# Altium schematic sheet RC and Telem.SchDoc("RC and Telem")
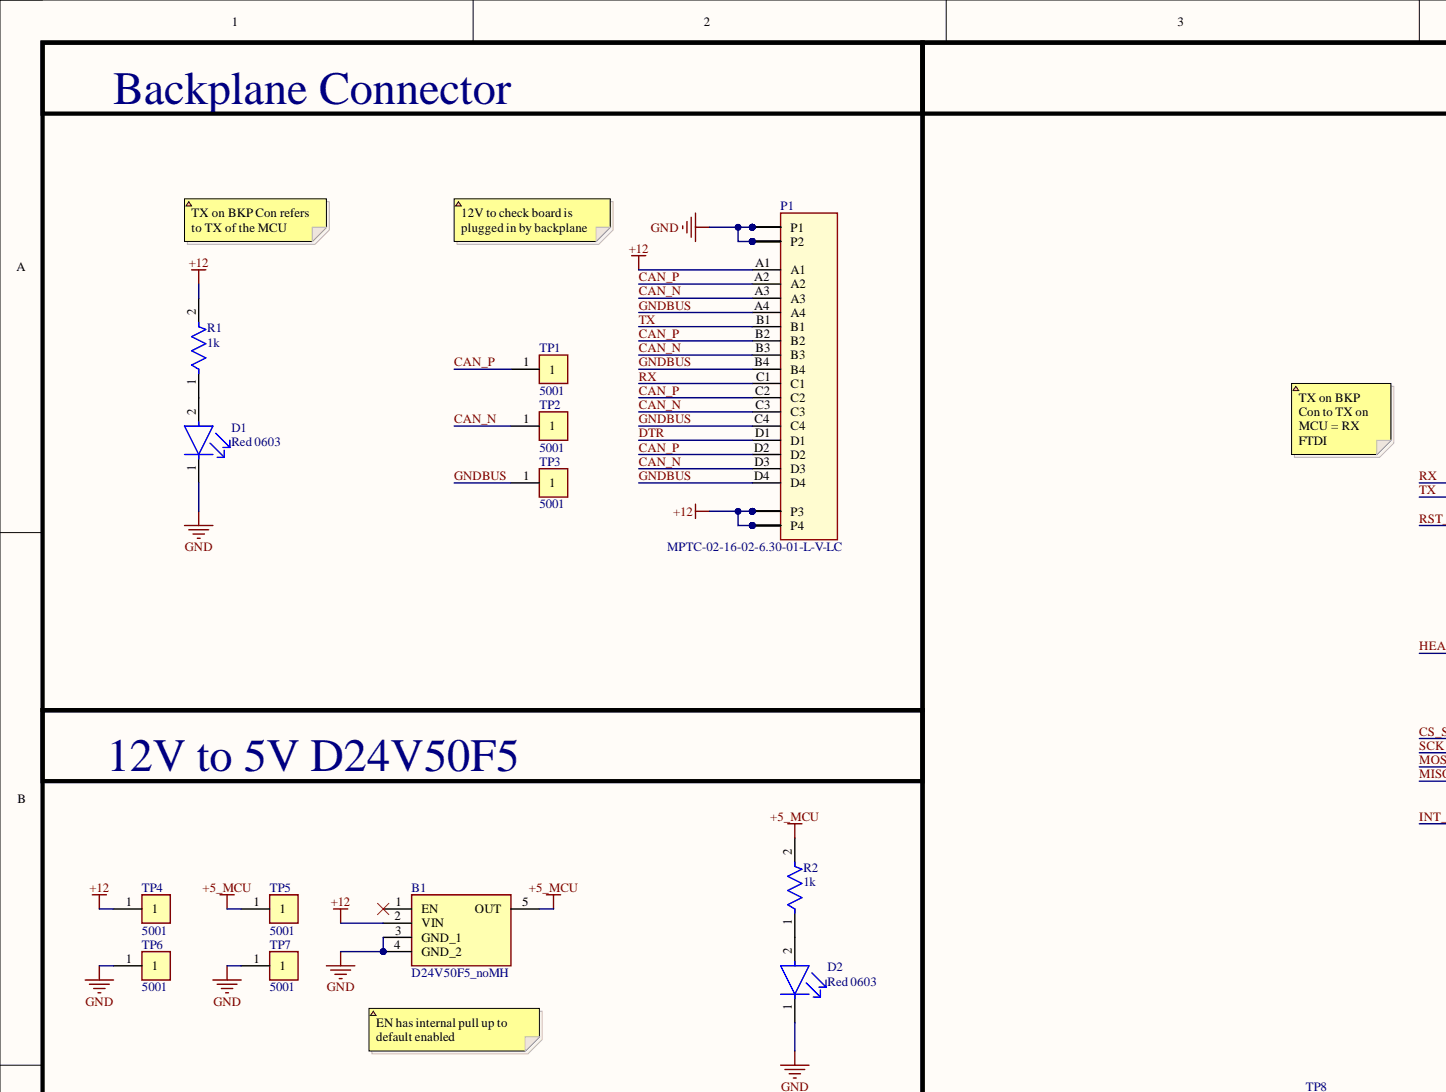
[diagram p0: RC and Telem.SchDoc, top left]
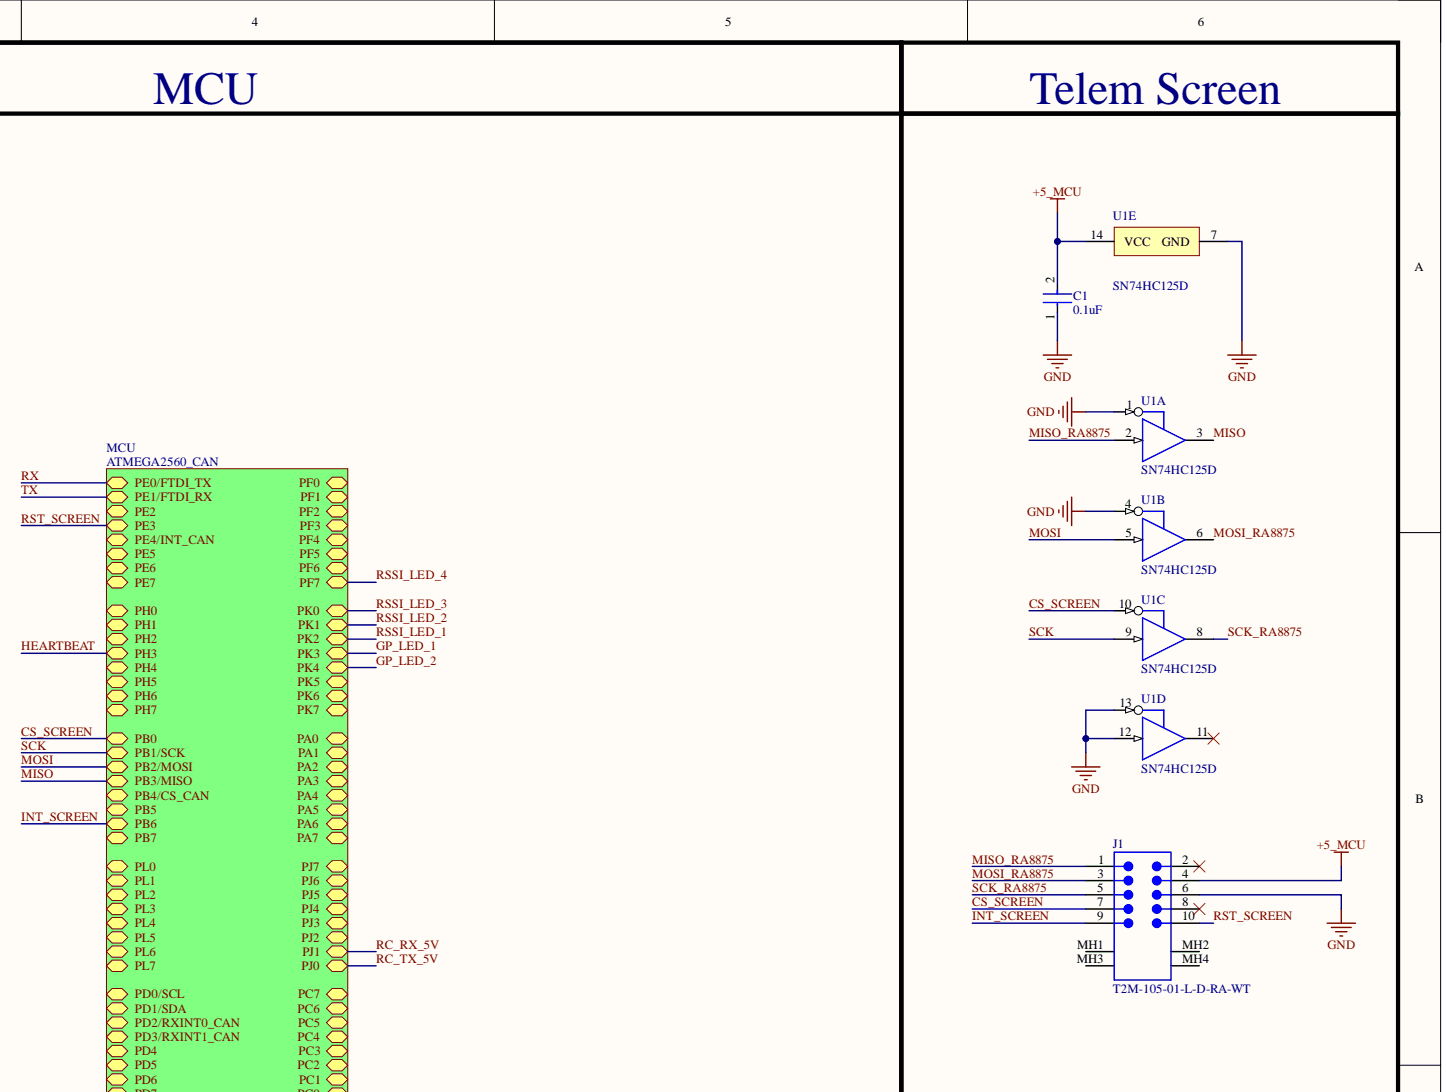
[diagram p1: RC and Telem.SchDoc, top right]
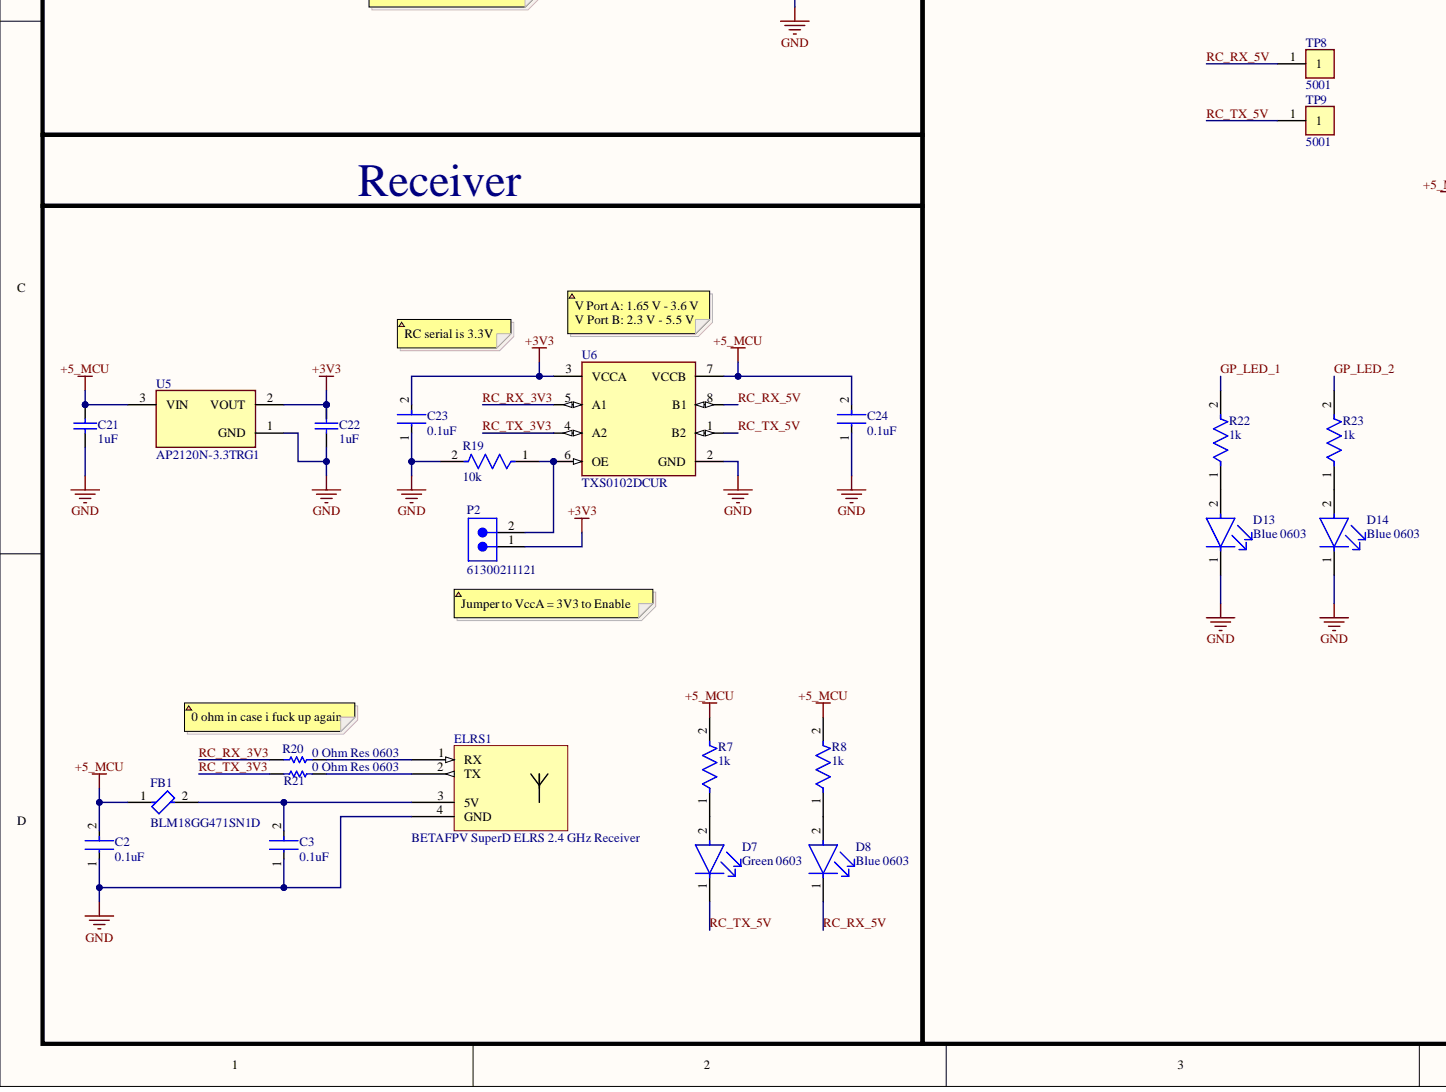
[diagram p2: RC and Telem.SchDoc, bottom left]
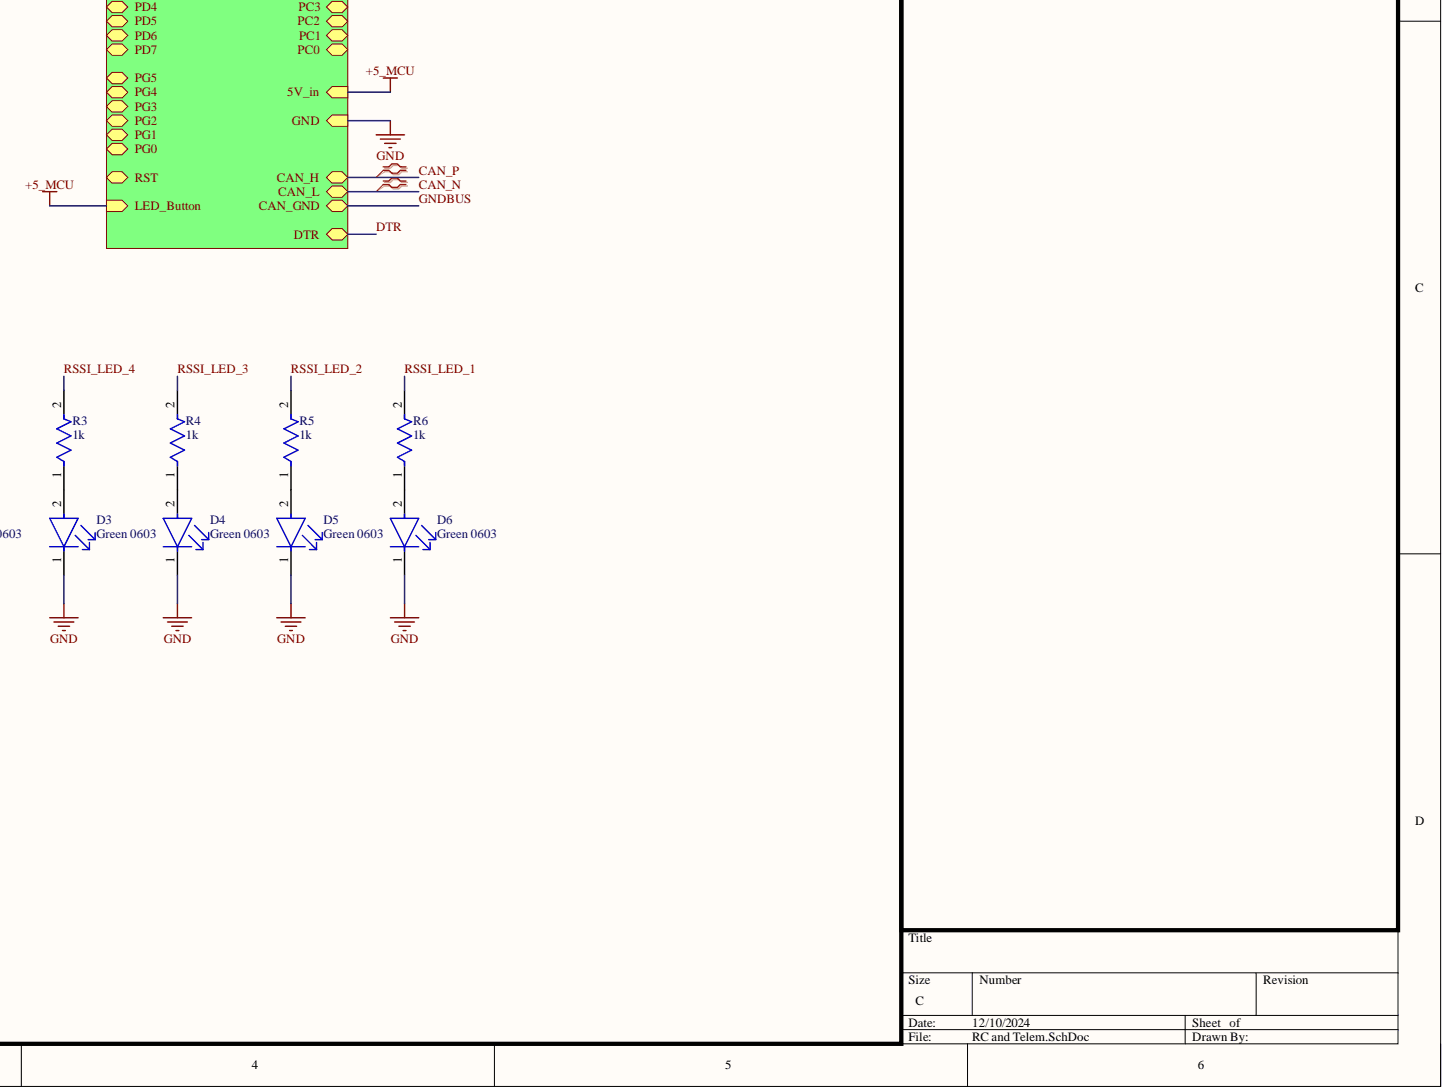
[diagram p3: RC and Telem.SchDoc, bottom right]

## Parts
B1  D24V50F5_noMH
C1  0.1uF
C2  0.1uF
C3  0.1uF
C21  1uF
C22  1uF
C23  0.1uF
C24  0.1uF
D1  Red 0603  Case Code (Imperial): 0603  Color: Red
D2  Red 0603  Case Code (Imperial): 0603  Color: Red
D3  Green 0603  Color: Green
D4  Green 0603  Case Code (Imperial): 0603  Color: Green
D5  Green 0603  Case Code (Imperial): 0603  Color: Green
D6  Green 0603  Case Code (Imperial): 0603  Color: Green
D7  Green 0603  Color: Green
D8  Blue 0603  Case Code (Imperial): 0603  Color: Blue
D13  Blue 0603  Case Code (Imperial): 0603  Color: Blue
D14  Blue 0603  Case Code (Imperial): 0603  Color: Blue
ELRS1  BETAFPV SuperD ELRS 2.4 GHz Receiver
FB1  BLM18GG471SN1D
J1  T2M-105-01-L-D-RA-WT
P1  MPTC-02-16-02-6.30-01-L-V-LC
P2  61300211121
R1  1k
R2  1k
R3  1k
R4  1k
R5  1k
R6  1k
R7  1k
R8  1k
R19  10k
R20  0 Ohm Res 0603
R21  0 Ohm Res 0603
R22  1k
R23  1k
TP1  5001
TP2  5001
TP3  5001
TP4  5001
TP5  5001
TP6  5001
TP7  5001
TP8  5001
TP9  5001
U1  SN74HC125D  (parts A,B,C,D,E)
U5  AP2120N-3.3TRG1
U6  TXS0102DCUR

## Nets
+3V3: C22.2 C23.2 P2.1 U5.2 U6.3(VCCA)
+5_MCU: B1.5(OUT) C1.2 C2.2 C21.2 C24.2 FB1.1 J1.4 R2.2 R7.2 R8.2 TP5.1 U1.14(VCC) U5.3 U6.7(VCCB)
+12: B1.2(VIN) P1.A1 P1.P3.1(P3) P1.P3.2 P1.P3.3 P1.P3.4 P1.P3.5 P1.P3.6 P1.P4.1(P4) P1.P4.2 P1.P4.3 P1.P4.4 P1.P4.5 P1.P4.6 R1.2 TP4.1
GND: B1.3(GND_1) B1.4(GND_2) C1.1 C2.1 C3.1 C21.1 C22.1 C23.1 C24.1 D1.1 D2.1 D3.1 D4.1 D5.1 D6.1 D13.1 D14.1 ELRS1.4(GND) J1.6 P1.P1.1 P1.P1.2 P1.P1.3 P1.P1.4 P1.P1.5 P1.P1.6 P1.P2.1(P2) P1.P2.2 P1.P2.3 P1.P2.4 P1.P2.5 P1.P2.6 R19.2 TP6.1 TP7.1 U1.1 U1.4 U1.7(GND) U1.12 U1.13 U5.1 U6.2(GND)
CAN_N: P1.A3 P1.B3 P1.C3 P1.D3 TP2.1
CAN_P: P1.A2 P1.B2 P1.C2 P1.D2 TP1.1
CS_SCREEN: J1.7 U1.10
DTR: P1.D1
GNDBUS: P1.A4 P1.B4 P1.C4 P1.D4 TP3.1
GP_LED_1: R22.2
GP_LED_2: R23.2
INT_SCREEN: J1.9
MISO: U1.3
MISO_RA8875: J1.1 U1.2
MOSI: U1.5
MOSI_RA8875: J1.3 U1.6
RC_RX_3V3: R20.1 U6.5(A1)
RC_RX_5V: D8.1 TP8.1 U6.8(B1)
RC_TX_3V3: R21.1 U6.4(A2)
RC_TX_5V: D7.1 TP9.1 U6.1(B2)
RSSI_LED_1: R6.2
RSSI_LED_2: R5.2
RSSI_LED_3: R4.2
RSSI_LED_4: R3.2
RST_SCREEN: J1.10
RX: P1.C1
SCK: U1.9
SCK_RA8875: J1.5 U1.8
TX: P1.B1
NetB1_1: B1.1(EN)
NetC3_2: C3.2 ELRS1.3(5V) FB1.2
NetD1_2: D1.2 R1.1
NetD2_2: D2.2 R2.1
NetD3_2: D3.2 R3.1
NetD4_2: D4.2 R4.1
NetD5_2: D5.2 R5.1
NetD6_2: D6.2 R6.1
NetD7_2: D7.2 R7.1
NetD8_2: D8.2 R8.1
NetD13_2: D13.2 R22.1
NetD14_2: D14.2 R23.1
NetELRS1_1: ELRS1.1(RX) R20.2
NetELRS1_2: ELRS1.2(TX) R21.2
NetJ1_2: J1.2
NetJ1_8: J1.8
NetJ1_MH1: J1.MH1
NetJ1_MH2: J1.MH2
NetJ1_MH3: J1.MH3
NetJ1_MH4: J1.MH4
NetP2_2: P2.2 R19.1 U6.6(OE)
NetU1_11: U1.11
HEARTBEAT:
PA0:
PA1:
PA2:
PA3:
PA4:
PA5:
PA6:
PA7:
PB4/CS_CAN:
PB5:
PB7:
PC0:
PC1:
PC2:
PC3:
PC4:
PC5:
PC6:
PC7:
PD0/SCL:
PD1/SDA:
PD2/RXINT0_CAN:
PD3/RXINT1_CAN:
PD4:
PD5:
PD6:
PD7:
PE2:
PE4/INT_CAN:
PE5:
PE6:
PE7:
PF0:
PF1:
PF2:
PF3:
PF4:
PF5:
PF6:
PG0:
PG1:
PG2:
PG3:
PG4:
PG5:
PH0:
PH1:
PH2:
PH4:
PH5:
PH6:
PH7:
PJ2:
PJ3:
PJ4:
PJ5:
PJ6:
PJ7:
PK5:
PK6:
PK7:
PL0:
PL1:
PL2:
PL3:
PL4:
PL5:
PL6:
PL7:
RST:

## Library data of the parts
B1 = CMP-030-000002-4: Pololu 5V, 5A Step-Down Voltage Regulator D24V50F5 with no Mounting Hole; Geometry.Height: 8.77mm; Component Kind: Standard; Datasheet Link: https://www.pololu.com/file/0J1436/d24v50f5-step-down-voltage-regulator-dimensions.pdf; Design Item ID: D24V50F5; Manufacturer_Name: Pololu; Manufacturer_Part_Number: D24V50F5; Source: D24V50F5.SchLib; Library Name: BumbleBee Autonomous Systems - NUS
C1-C3 C23 C24 = CMP-2006-02218-2: GCJ188R71C104KA01D. Cap Ceramic 0.1uF 16V X7R 10% Pad SMD 0603 Soft Termination +125°C Automotive T/R; Capacitance: 100nF; Case Code (Imperial): 0603; Case Code (Metric): 1608; Case/Package: 0603; Component Kind: Standard; Depth: 0.8mm; Dielectric: X7R; Features: Soft Termination; Height: 0.8mm; Length: 1.6mm; Library Name: Altium Content Vault; Max Operating Temperature: 125°C; Min Operating Temperature: -55°C; Mount: Surface Mount; Package Quantity: 1; Packaging: Tape and Reel; Radiation Hardening: No; Ratings: AEC-Q200; Termination: SMD/SMT; Thickness: 0.035inch; Tolerance: 10%; Voltage Rating: 16V; Voltage Rating (DC): 16V; Width: 0.031inch
C21 C22 = CMP-1035-02736-2: 0603YC105JAT2A; Manufacturer: AVX Interconnect / Elco; Component Kind: Standard; Library Name: Altium Content Vault; Part Number: 0603YC105JAT2A
D1 D2 = CMP-00010-2: Led Red Diffused 0603 SMD; Manufacturer Part No.: 150060RS55040; Dominant Wavelength: 624nm; Forward Voltage: 2V; Lens Size: 0.8mm; Lens Style: Flat; Luminous Intensity: 90mcd; Test Current: 20mA; Viewing Angle: 140°; Case Code (Metric): 1608; Case/Package: 0603; Component Kind: Standard; Datasheet: https://www.farnell.com/datasheets/2621644.pdf?src-supplier=Farnell; Height: 0.5mm; Length: 1.6mm; Lens Transparency: Diffused; Mounting Technology: Surface Mount; Packaging: Cut Tape; RoHS Compliant: Yes; Series: WL-SMDC; Width: 0.8mm; Library Name: BumbleBee Autonomous Systems - NUS
D3-D7 = CMP-00011-1: Led, Green, 570 Nm, 2 V, 30 Ma, 40 Mcd Rohs Compliant: Yes; Manufacturer Part No.: 150060VS75000; Dominant Wavelength: 570nm; Forward Current: 20mA; Forward Voltage: 2V; Lens Color: Colorless; Lens Size: 0.7mm; Lens Style: Rectangular,Flat; Lens Transparency: Clear; Luminous Intensity: 40mcd; Power Rating: 72mW; Reverse Voltage (DC): 5V; Viewing Angle: 140°; Case Code (Metric): 1608; Case/Package: 0603; Component Kind: Standard; Height: 0.8mm; Illumination Color: Green; Length: 1.6mm; Max Operating Temperature: 85°C; Min Operating Temperature: -40°C; Mounting Technology: Surface Mount; Package Quantity: 4000; Packaging: Cut Tape; Pins: 2; RoHS Compliant: Yes; Series: WL-SMCW; Test Current: 20mA; Weight: 0.000882oz; Width: 0.8mm; Library Name: BumbleBee Autonomous Systems - NUS
  D3 D7: Case Code (Imperial): 0603
D8 D13 D14 = CMP-00012-1: Led, Blue, 470 Nm, 3.2 V, 30 Ma, 145 Mcd Rohs Compliant: Yes; Manufacturer Part No.: 150060BS75000; Dominant Wavelength: 470nm; Forward Current: 30mA; Forward Voltage: 3.2V; Lens Color: Colorless; Lens Size: 0.7mm; Lens Style: Clear,Flat,Rectangular; Lens Transparency: Clear; Luminous Intensity: 145mcd; Test Current: 20mA; Viewing Angle: 140°; Case Code (Metric): 1608; Case/Package: 0603; Component Kind: Standard; Height: 0.8mm; Length: 1.6mm; Max Operating Temperature: 85°C; Min Operating Temperature: -40°C; Mounting Technology: Surface Mount; Package Quantity: 1; Packaging: Cut Tape; Reach SVHC Compliant: Unknown; RoHS Compliant: Yes; Series: WL-SMCW; Wavelength: 470nm; Width: 0.8mm; Library Name: BumbleBee Autonomous Systems - NUS
ELRS1 = CMP-00058-3: https://betafpv.com/collections/rx/products/superd-elrs-2-4g-diversity-receiver?variant=39995057668230; Component Kind: Standard; Library Name: BumbleBee Autonomous Systems - NUS
FB1 = CMP-0686-00593-3: FERRITE BEAD 470 OHM 0603 1LN; Current Rating: 200mA; DC Resistance (DCR): 300mR; Impedance: 470R; Material: Steel; Number of Circuits: 1; Resistance: 1.3R; Test Frequency: 100MHz; Tolerance: 25%; Case Code (Imperial): 0603; Case Code (Metric): 1608; Case/Package: 0603; Component Kind: Standard; Contact Plating: Tin; Core Material: Ferrite; Depth: 0.8mm; Height: 0.8mm; Lead Free: Lead Free; Length: 1.6mm; Library Name: Altium Content Vault; Max Current Rating: 200mA; Max DC Current: 200mA; Max Operating Temperature: 125°C; Min Operating Temperature: -55°C; Number of Lines: 1; Number of Pins: 2; Package Quantity: 1; Packaging: Tape and Reel; REACH SVHC: No SVHC; Termination: Flat; Width: 0.8mm
J1 = CMP-1029-00151-1: High Reliability Cable Header, Through-hole, Right Angle, -55 to 125 degC, 2 mm Pitch, 14-Pin, Male, RoHS; Manufacturer: Samtec; Pitch: 2 mm; Component Kind: Standard; ComponentLink1Description: Manufacturer URL; ComponentLink1URL: http://www.samtec.com; ComponentLink2Description: Datasheet; ComponentLink2URL: http://d2a072wq521u33.cloudfront.net/catalog_english//t2m.pdf; ComponentLink3Description: Package Specification; ComponentLink3URL: http://www.samtec.com/documents/webfiles/cpdf/T2M-1XX-XX-X-D-XX-XX-MKT.pdf; DatasheetVersion: F-214; Library Name: Altium Content Vault; Mounting Technology: Through-hole; Orientation: Right Angle; PackageDescription: 2mm Shrouded Terminal Strip; PackageReference: T2M-105-01-X-D-RA-WT; PackageVersion: V, 3/2009; PartNumber: T2M-105-01-L-D-RA-WT; RoHS: True; Temperature Range: -55 to 125 degC
P1 = CMP-141-000007-3: Conn Power HDR 4Power/16Signal POS Solder ST Thru-Hole 40 Terminal 1 Port; Availability: Unavailable; Component Kind: Standard; Component Type: Standard; Design Item ID: MPTC-02-16-02-6.30-01-L-V-LC; MP: MPTC-02-16-02-6.30-01-L-V-LC; Package: None; Pin Count: 40; Price: None; Source: MPTC-02-16-02-6.30-01-L-V-LC.SchLib; Library Name: BumbleBee Autonomous Systems - NUS
P2 = CMP-1712-00002-5: NexarPartId: 20081794; Connector Type: Male Pin; Contact Resistance: 20mΩ; Current Rating: 3A; Gender: Male; Number of Contacts: 2; Operating Supply Voltage: 250V; Pitch: 2.54mm; Color: Black; Component Kind: Standard; Contact Gender: Male; Contact Material: Copper; Contact Mating Length: 5.9944mm; Contact Plating: Gold; Height: 8.94mm; Housing Material: PBT; Lead Free: Lead Free; Lead Pitch: 2.54mm; Library Name: Altium Content Vault; Max Operating Temperature: 125°C; Min Operating Temperature: -40°C; Mount: Through Hole; Number of Pins: 2; Number of Positions: 2; Number of Rows: 1; Orientation: Vertical; Packaging: Bulk; REACH SVHC: No SVHC; RoHS: Compliant; Termination: Through Hole; Voltage Rating: 250V
R1-R8 R22 R23 = CMP-1659-00009-5: RC0603FR-071KL. RES 1K OHM 1% 1/10W 0603; Max Power Dissipation: 100mW; Resistance: 1kR; Temperature Coefficient: 100ppm/°C; Voltage Rating: 50V; Case Code (Imperial): 0603; Case Code (Metric): 1608; Case/Package: 0603; Component Kind: Standard; Construction: Rectangular; Contact Plating: Tin; Depth: 0.8mm; Features: Moisture Resistant; Height: 0.45mm; Lead Free: Lead Free; Length: 1.6mm; Library Name: Altium Content Vault; Max Operating Temperature: 155°C; Military Standard: Not; Min Operating Temperature: -55°C; Number of Terminations: 2; Packaging: Tape and Reel; Power Rating: 100mW; Radiation Hardening: No; REACH SVHC: No SVHC; Resistance Tolerance: 1%; Resistor Type: Thick Film; RoHS Compliant: Yes; Series: RC; Size Code: 0603; Technology: THICK FILM; Termination: SMD/SMT; Tolerance: 1%
R19 = CMP-05422-000107-1: ERJ-PB3B1002V. RES SMD 10K OHM 0.1% 1/5W 0603; Max Power Dissipation: 200mW; Resistance: 10kR; Temperature Coefficient: 50ppm/°C; Case Code (Imperial): 0603; Case Code (Metric): 1608; Case/Package: 0603; Component Kind: Standard; Features: Automotive AEC-Q200; Height - Seated (Max): 0.022inch; Length: 0.063inch; Library Name: Altium Content Vault; Max Operating Temperature: 155°C; Min Operating Temperature: -55°C; Number of Terminations: 2; Packaging: Tape and Reel; Resistor Type: Thick Film; Tolerance: 0.1%; Width: 0.032inch
R20 R21 = CMP-00053-1: RES SMD 0 OHM JUMPER 1/10W 0603; Max Power Dissipation: 100mW; Resistance Tolerance: 5%; Temperature Coefficient: 200ppm/°C; Tolerance: 1%; Value: 0mR; Voltage Rating: 50V; Case Code (Imperial): 0603; Case Code (Metric): 1608; Case/Package: 0603; Component Kind: Standard; Construction: Rectangular; Contact Plating: Tin,Matte; Depth: 0.8mm; Features: Moisture Resistant; Height: 0.45mm; Lead Free: Lead Free; Length: 1.6mm; Max Operating Temperature: 155°C; Military Standard: Not; Min Operating Temperature: -55°C; Number of Terminations: 2; Package Quantity: 5000; Packaging: Tape and Reel; Pins: 2; Power Rating: 100mW; Reach SVHC Compliant: Unknown; Resistor Type: Thick Film; RoHS Compliant: Yes; Series: RC; Technology: THICK FILM; Termination: SMD/SMT; Voltage Rating (DC): 50V; Width: 0.8mm; Library Name: BumbleBee Autonomous Systems - NUS
TP1-TP9 = CMP-2000-05181-2: PC TEST POINT MINIATURE BLACK; Body Material: Bronze; Hole Diameter: 1.6mm; Inside Diameter: 1.27mm; Insulation: Insulated; Number of Contacts: 1; Outside Diameter: 2.54mm; Plating: Silver; Color: Black; Component Kind: Standard; Contact Material: Bronze; Contact Plating: Silver; Diameter: 2.54mm; Flammability Rating: UL94 V-0; Height: 0.3inch; Housing Color: Black; Insulation Material: Nylon; Lead Free: Lead Free; Length: 7.62mm; Library Name: Altium Content Vault; Material: Nylon; Mount: Through Hole; Number of Ports: 1; Orientation: Straight; Package Quantity: 100; Packaging: Bulk; REACH SVHC: No SVHC; RoHS Compliant: Yes; Series: THM; Termination: Through Hole
U1 = CMP-00013-3: SN74HC125 Quadruple Bus Buffer G; High Level Output Current: -7.8mA; Logic Function: Buffer,Inverting; Low Level Output Current: 7.8mA; Max Supply Voltage: 6V; Min Supply Voltage: 2V; Number of Bits: 4; Number of Bits per Element: 1; Operating Supply Voltage: 5V; Output Type: 3-STATE; Polarity: Non-Inverting; Propagation Delay: 190ns; Quiescent Current: 8uA; Turn-On Delay Time: 150ns; Case/Package: SOIC; Component Kind: Standard; Height: 1.58mm; Lead Free: Lead Free; Length: 8.65mm; Max Operating Temperature: 85°C; Max Output current: 7.8mA; Min Operating Temperature: -40°C; Mounting Technology: Surface Mount; Number of Channels: 4; Number of Circuits: 4; Number of Elements: 4; Number of Input Lines: 4; Number of Inputs: 4; Number of Output Lines: 4; Number of Outputs: 4; Output Current: 7.8mA; Package Quantity: 50; Packaging: Rail/Tube; Pins: 14; Radiation Hardening: No; Reach SVHC Compliant: No; RoHS Compliant: Yes; Series: 74HC; Technology: CMOS; Width: 3.91mm; Library Name: BumbleBee Autonomous Systems - NUS
U5 = CMP-12756-000008-1: IC REG LINEAR 3.3V 150MA SOT23; Manufacturer: Diodes Inc.; Accuracy Percentage: 2%; Dropout Voltage: 300mV; Max Junction Temp: +85°C; Max Supply Voltage: 6V; Min Supply Voltage: 2V; Nominal Supply Current: 25uA; Number Of Outputs: 1; Output Type: Fixed; Standoff Height: 0.013mm; Automotive: No; Category: IC; Component Kind: Standard; ComponentLink2Description: Datasheet; ComponentLink2URL: https://www.diodes.com/assets/Package-Files/SOT23.pdf; Device Class L1: Integrated Circuits (ICs); Device Class L2: Power Management ICs; Device Class L3: Voltage Regulators - Linear; Digikey Description: IC REG LINEAR 3.3V 150MA SOT23; Footprint Url: https://www.diodes.com/assets/Package-Files/SOT23.pdf; Height: 1.1mm; Ipc Land Pattern Name: SOT92P240X102-3; Lead Free: Yes; Library Name: Altium Content Vault; Manufacturer Part Number: AP2120N-3.3TRG1; Mouser Description: AP2120 Series 3.3 V 0.15 A Surface Mount CMOS LDO Regulator - SOT23; Octopart: https://octopart.com/ap2120n-3.3trg1-diodes+inc.-30954573; Output Current: 150mA; Output Voltage: 3.3V; Package: SOT23; RoHS: TRUE; Temperature: -40°C to +85°C; Temperature Range High: +85°C; Temperature Range Low: -40°C
U6 = CMP-0859-00001-2: IC TRNSLTR BIDIRECTIONAL US8; Manufacturer: Texas Instruments; Data Rate: 24Mbits/s; Logic Function Desc: Bidirectional Voltage-Level Translator; Max Junction Temp: +150°C; Max Supply Voltage: 5.5V; Min Supply Voltage: 1.65V; Nominal Supply Current: 1-14.4uA; Number Of Circuits: 1; Number Of Inputs: 2; Standoff Height: 0mm; V A Max: 3.6V; V B Min: 2.3V; Ambient Temperature Range High: +85°C; Ambient Temperature Range Low: -40°C; Automotive: No; Category: IC; Component Kind: Standard; ComponentLink2Description: Datasheet; ComponentLink2URL: http://www.ti.com/lit/ds/symlink/txs0102.pdf; Device Class L1: Integrated Circuits (ICs); Device Class L2: Logic ICs; Device Class L3: Voltage Level Shifters; Digikey Description: IC TRNSLTR BIDIRECTIONAL US8; Height: 0.9mm; Lead Free: Yes; Library Name: Altium Content Vault; Manufacturer Part Number: TXS0102DCUR; Mouser Description: Translation - Voltage Levels 2-Bit Bi-Directional Voltage-Level-Trans; Number Of Outputs: 2; Octopart: https://octopart.com/txs0102dcur-texas+instruments-5414308; Package: DCU0008A; RoHS: TRUE; Temperature: -40°C to +85°C; Temperature Range High: +85°C; Temperature Range Low: -40°C; V A Min: 1.65V; V B Max: 5.5V
